# Altium schematic sheet DC Power Conversion.SchDoc
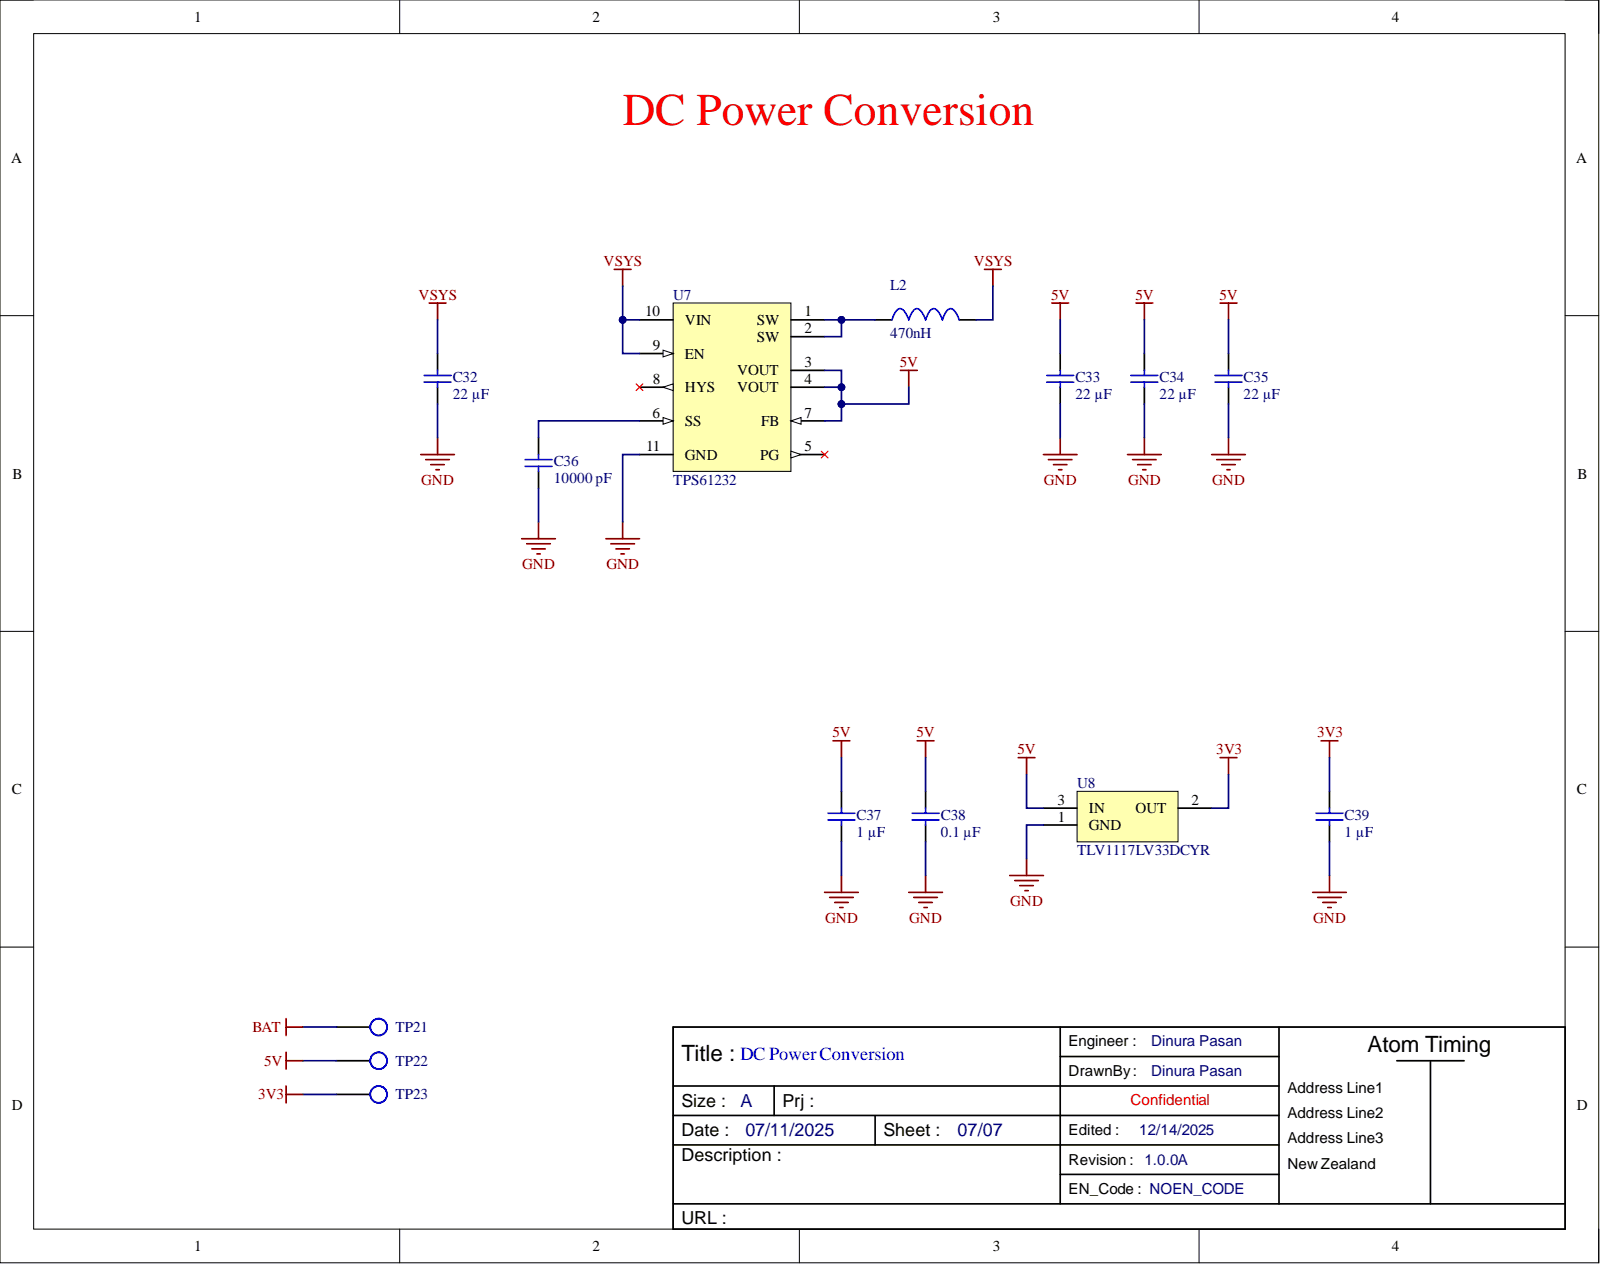
[diagram p0: DC Power Conversion.SchDoc]

## Parts
C32  22 µF  [TDK_CGA1206N]
C33  22 µF  [TDK_CGA1206N]
C34  22 µF  [TDK_CGA1206N]
C35  22 µF  [TDK_CGA1206N]
C36  10000 pF  [TDK_CGA0402N]
C37  1 µF  [TDK_CGA0805N]
C38  0.1 µF  [TDK_CGA0805N]
C39  1 µF  [TDK_CGA0805N]
L2  470nH  [XFL4015-471MEC]
TP21  TestPoint  [TESTPOINT]  (value not drawn)
TP22  TestPoint  [TESTPOINT]  (value not drawn)
TP23  TestPoint  [TESTPOINT]  (value not drawn)
U7  TPS61232  [TI-DRC0010G]
U8  TLV1117LV33DCYR  [TLV1117LV33DCYR]

## Nets
3V3: C39.2 TP23.1 U8.2
5V: C33.2 C34.2 C35.2 C37.2 C38.2 TP22.1 U7.3(VOUT) U7.4(VOUT) U7.7(FB) U8.3
BAT: TP21.1
GND: C32.1 C33.1 C34.1 C35.1 C36.1 C37.1 C38.1 C39.1 U7.11(GND) U8.1
VSYS: C32.2 L2.2 U7.9(EN) U7.10(VIN)
NetC36_2: C36.2 U7.6(SS)
NetL2_1: L2.1 U7.1(SW) U7.2(SW)

Not connected: U7 5(PG) 8(HYS)

## Library data of the parts
C32-C35 = CGA5L1X5R1C226M160AC: 22 µF ±20% 16V X5R -55°C ~ 85°C 1206 (3216 Metric); Manufacturer: TDK Corporation; Database Table Name: Sheet1$; Eco Plan: RoHS & Green; Initial Voltage: 0V; TEMP: 25; Value: 22u; Capacitance: 22 µF; Category: Ceramic Capacitor (MLCC); Component Kind: Standard; Datasheet: https://product.tdk.com/system/files/dam/doc/product/capacitor/ceramic/mlcc/catalog/mlcc_automotive_general_en.pdf; Library Name: MLCC.DbLib; Manufacturer Part Number: CGA5L1X5R1C226M160AC; Operating Temperature: -55°C ~ 85°C; Package / Case: 1206 (3216 Metric); Ratings: AEC-Q200; Series: CGA; Simulation: C_Capacitor_Default; Size / Dimension: 0.126" L x 0.063" W (3.20mm x 1.60mm); Supplier 1: Digi-Key; Supplier Part Number 1: 445-12847-2-ND; Temperature Coefficient: X5R; Thickness (Max): 0.075" (1.90mm); Tolerance: ±20%; Voltage - Rated: 16V
C36 = CGA2B3X7R1H103K050BB: 10000 pF ±10% 50V X7R -55°C ~ 125°C 0402 (1005 Metric); Manufacturer: TDK Corporation; Database Table Name: Sheet1$; Eco Plan: RoHS & Green; Initial Voltage: 0V; TEMP: 25; Value: 10000p; Capacitance: 10000 pF; Category: Ceramic Capacitor (MLCC); Component Kind: Standard; Datasheet: https://product.tdk.com/system/files/dam/doc/product/capacitor/ceramic/mlcc/catalog/mlcc_automotive_general_en.pdf; Library Name: MLCC.DbLib; Manufacturer Part Number: CGA2B3X7R1H103K050BB; Operating Temperature: -55°C ~ 125°C; Package / Case: 0402 (1005 Metric); Ratings: AEC-Q200; Series: CGA; Simulation: C_Capacitor_Default; Size / Dimension: 0.039" L x 0.020" W (1.00mm x 0.50mm); Supplier 1: Digi-Key; Supplier Part Number 1: 445-6893-2-ND; Temperature Coefficient: X7R; Thickness (Max): 0.022" (0.55mm); Tolerance: ±10%; Voltage - Rated: 50V
C37 C39 = CGA4J3X7R1H105K125AB: 1 µF ±10% 50V X7R -55°C ~ 125°C 0805 (2012 Metric); Manufacturer: TDK Corporation; Database Table Name: Sheet1$; Eco Plan: RoHS & Green; Initial Voltage: 0V; TEMP: 25; Value: 1u; Capacitance: 1 µF; Category: Ceramic Capacitor (MLCC); Component Kind: Standard; Datasheet: https://product.tdk.com/system/files/dam/doc/product/capacitor/ceramic/mlcc/catalog/mlcc_automotive_general_en.pdf; Library Name: MLCC.DbLib; Manufacturer Part Number: CGA4J3X7R1H105K125AB; Operating Temperature: -55°C ~ 125°C; Package / Case: 0805 (2012 Metric); Ratings: AEC-Q200; Series: CGA; Simulation: C_Capacitor_Default; Size / Dimension: 0.079" L x 0.049" W (2.00mm x 1.25mm); Supplier 1: Digi-Key; Supplier Part Number 1: 445-6961-2-ND; Temperature Coefficient: X7R; Thickness (Max): 0.059" (1.50mm); Tolerance: ±10%; Voltage - Rated: 50V
C38 = CGA4J2X7R2A104K125AE: 0.1 µF ±10% 100V X7R -55°C ~ 125°C 0805 (2012 Metric); Manufacturer: TDK Corporation; Database Table Name: Sheet1$; Eco Plan: RoHS & Green; Initial Voltage: 0V; TEMP: 25; Value: 0.1u; Capacitance: 0.1 µF; Category: Ceramic Capacitor (MLCC); Component Kind: Standard; Datasheet: https://product.tdk.com/system/files/dam/doc/product/capacitor/ceramic/mlcc/catalog/mlcc_automotive_general_en.pdf; Library Name: MLCC.DbLib; Manufacturer Part Number: CGA4J2X7R2A104K125AE; Operating Temperature: -55°C ~ 125°C; Package / Case: 0805 (2012 Metric); Ratings: AEC-Q200; Series: CGA; Simulation: C_Capacitor_Default; Size / Dimension: 0.079" L x 0.049" W (2.00mm x 1.25mm); Supplier 1: Digi-Key; Supplier Part Number 1: 445-15961-2-ND; Temperature Coefficient: X7R; Thickness (Max): 0.059" (1.50mm); Tolerance: ±10%; Voltage - Rated: 100V
L2 = XFL4015-471MEC: 470 nH Shielded Molded Inductor 9.1 A 8.36mOhm Max 1616 (4040 Metric); Manufacturer 1: Coilcraft; Case/Package 1: 1616; Category 1: Fixed Inductors; Core Material: Composite; DC Resistance (DCR): 8.36mΩ; Description 1: General Purpose Inductor, 0.47uH, 20%, 1 Element, Composite-Core, SMD, 1616; Max Current Rating: 11.2A; Number of Circuits: 1; Saturation Current: 3.5A; Self Resonant Frequency: 89MHz; Shielding: Yes; Test Frequency: 1MHz; Tolerance: 20%; Ambient Temperature Range High: 125°C; Component Kind: Standard; ComponentLink1Description: https://datasheet.octopart.com:443/XFL4015-471MEC-Coilcraft-datasheet-180122275.pdf?src-supplier=Newark; ComponentLink1URL: https://datasheet.octopart.com:443/XFL4015-471MEC-Coilcraft-datasheet-180122275.pdf?src-supplier=Newark; ComponentLink2Description: https://datasheet.octopart.com:443/XFL4015-471MEC-Coilcraft-datasheet-14354400.pdf?src-supplier=Newark; ComponentLink2URL: https://datasheet.octopart.com:443/XFL4015-471MEC-Coilcraft-datasheet-14354400.pdf?src-supplier=Newark; Height: 1.6mm; Inductance: 470nH; Lead Free 1: Contains Lead; Length: 4.3mm; Library Name: Components.IntLib; Lifecycle Status: Production; Manufacturer Part Number 1: XFL4015-471MEC; Max DC Current: 11.2A; Max Operating Temperature 1: 125°C; Min Operating Temperature 1: -40°C; Number of Terminals: 2; REACH SVHC: Yes; RMS Current (Irms): 11.2A; RoHS 1: Compliant; Series Resistance: 8.36mΩ; Supplier 1: LCSC; Supplier Part Number 1: C18221164; Termination: SMD/SMT; Width: 4mm
TP21-TP23 = TestPoint: 1mm Test Point; Component Kind: Standard; Library Name: Common_library.IntLib
U7 = TPS61232: 5-A, 5-V Fixed Output Voltage High Efficiency Synchronous Boost Converter; Manufacturer 1: Texas Instruments; Manufacturer Lifecycle Status: ACTIVE; Manufacturer Part Number 1: TPS61232DRCR; Category 1: Voltage Regulators - Switching; Description 1: Switching Regulator, Current-mode, 6A, 2000kHz Switching Freq-Max, BICMOS, PDSO10; Efficiency: 96%; Max Duty Cycle: 90%; Max Input Voltage: 5.5V; Max Junction Temperature (Tj): 125°C; Max Output Current 1: 2.1A; Min Input Voltage: 2.3V; Mount 1: Surface Mount; Number of Outputs 1: 1; Number of Pins 1: 10; Output Current 1: 5A; Output Voltage 1: 5V; Quiescent Current: 35µA; Switching Frequency: 2MHz; Component Kind: Standard; ComponentLink1Description: https://octopart.com:443/opatz8j6/c1?t=2GWtLKWaxkQjmDKCMiYp00vJSSZ6Dqq9CA-ZWt9kwYM6DX5ubETJ-My9t6Qr4BZEXr19lv6A2i-CshoPxpi94K44nAW2jEyEE6zKs7z5-oRQGsJxNSBgvcb6f20WCk7LcdIe30JwLkG3nK1R1Xb9Hxk3Cg-SRFlUkcLkSJVF01dwP3l53ZDBSz9xJsjXTl4r7N5XTrMGIaymN0CwyxUnNU4cmdNf9vRAvJvr57abplrAd1pVnWo8BucYefAgIB4yZHuUHMiIzXwaB4SkerCA1WYVJC1Conw6VGBGuORAGHjLjR_x7UK9rfgU-A0cQOeklwRook9k_VAM3E9OKgiQykZE8gQDOaTounc8ydU2ZqOfHFZe-mLkJ7n_izJa3DP4XXx4mos&src-supplier=Texas+Instruments; ComponentLink1URL: https://octopart.com:443/opatz8j6/c1?t=2GWtLKWaxkQjmDKCMiYp00vJSSZ6Dqq9CA-ZWt9kwYM6DX5ubETJ-My9t6Qr4BZEXr19lv6A2i-CshoPxpi94K44nAW2jEyEE6zKs7z5-oRQGsJxNSBgvcb6f20WCk7LcdIe30JwLkG3nK1R1Xb9Hxk3Cg-SRFlUkcLkSJVF01dwP3l53ZDBSz9xJsjXTl4r7N5XTrMGIaymN0CwyxUnNU4cmdNf9vRAvJvr57abplrAd1pVnWo8BucYefAgIB4yZHuUHMiIzXwaB4SkerCA1WYVJC1Conw6VGBGuORAGHjLjR_x7UK9rfgU-A0cQOeklwRook9k_VAM3E9OKgiQykZE8gQDOaTounc8ydU2ZqOfHFZe-mLkJ7n_izJa3DP4XXx4mos&src-supplier=Texas+Instruments; ComponentLink2Description: https://sigma.octopart.com:443/104365911/technical_drawing/Texas-Instruments-TPS61232DRCR.pdf?src-supplier=Texas+Instruments; ComponentLink2URL: https://sigma.octopart.com:443/104365911/technical_drawing/Texas-Instruments-TPS61232DRCR.pdf?src-supplier=Texas+Instruments; ComponentLink3Description: https://datasheet.octopart.com:443/TPS61232DRCR-Texas-Instruments-datasheet-41205295.pdf?src-supplier=Texas+Instruments; ComponentLink3URL: https://datasheet.octopart.com:443/TPS61232DRCR-Texas-Instruments-datasheet-41205295.pdf?src-supplier=Texas+Instruments; ComponentLink4Description: https://datasheet.octopart.com:443/TPS61232DRCR-Texas-Instruments-datasheet-32868995.pdf?src-supplier=Texas+Instruments; ComponentLink4URL: https://datasheet.octopart.com:443/TPS61232DRCR-Texas-Instruments-datasheet-32868995.pdf?src-supplier=Texas+Instruments; ComponentLink5Description: https://datasheet.octopart.com:443/TPS61232DRCR-Texas-Instruments-datasheet-27316741.pdf?src-supplier=Texas+Instruments; ComponentLink5URL: https://datasheet.octopart.com:443/TPS61232DRCR-Texas-Instruments-datasheet-27316741.pdf?src-supplier=Texas+Instruments; ComponentLink6Description: https://sigma.octopart.com:443/49250247/technical_drawing/Texas-Instruments-TPS61232DRCR.pdf?src-supplier=Texas+Instruments; ComponentLink6URL: https://sigma.octopart.com:443/49250247/technical_drawing/Texas-Instruments-TPS61232DRCR.pdf?src-supplier=Texas+Instruments; ComponentLink7Description: https://datasheet.octopart.com:443/TPS61232DRCR-Texas-Instruments-datasheet-148764816.pdf?src-supplier=Upverter; ComponentLink7URL: https://datasheet.octopart.com:443/TPS61232DRCR-Texas-Instruments-datasheet-148764816.pdf?src-supplier=Upverter; ComponentLink8Description: https://datasheet.octopart.com:443/TPS61232DRCR-Texas-Instruments-datasheet-159118193.pdf?src-supplier=LCSC; ComponentLink8URL: https://datasheet.octopart.com:443/TPS61232DRCR-Texas-Instruments-datasheet-159118193.pdf?src-supplier=LCSC; ComponentLink9Description: http://sigma.octopart.com:80/conflict_mineral_statement/Texas-Instruments-company-63.pdf?src-supplier=Compliance+Statements; ComponentLink9URL: http://sigma.octopart.com:80/conflict_mineral_statement/Texas-Instruments-company-63.pdf?src-supplier=Compliance+Statements; ComponentLink10Description: http://sigma.octopart.com:80/reach_statement/Texas-Instruments-company-64.pdf?src-supplier=Compliance+Statements; ComponentLink10URL: http://sigma.octopart.com:80/reach_statement/Texas-Instruments-company-64.pdf?src-supplier=Compliance+Statements; Contact Plating: Gold; Height: 1mm; Lead Free 1: Lead Free; Length: 3mm; Library Name: ICs.IntLib; Lifecycle Status: Production; Manufacturer Part Number 2: TPS61232DRCT; Max Operating Temperature 1: 85°C; Max Supply Voltage 1: 5.5V; Min Operating Temperature 1: -40°C; Min Supply Voltage 1: 2.3V; Operating Supply Voltage: 5.5V; Output Type: Fixed; RoHS 1: Compliant; Schedule B: 8542390000, 8542390000|8542390000|8542390000|8542390000|8542390000; Simulation: TPS61232_TRANS; Supplier 1: DigiKey; Supplier 2: DigiKey; Supplier 3: DigiKey; Supplier 4: Mouser; Supplier 5: LCSC; Supplier 6: Arrow Electronics; Supplier 7: DigiKey; Supplier 8: DigiKey; Supplier 9: DigiKey; Supplier 10: Mouser; Supplier Part Number 1: 296-44498-1-ND; Supplier Part Number 2: 296-44498-6-ND; Supplier Part Number 3: 296-44498-2-ND; Supplier Part Number 4: 595-TPS61232DRCR; Supplier Part Number 5: C544315; Supplier Part Number 6: TPS61232DRCT; Supplier Part Number 7: 296-37990-1-ND; Supplier Part Number 8: 296-37990-6-ND; Supplier Part Number 9: 296-37990-2-ND; Supplier Part Number 10: 595-TPS61232DRCT; Thickness: 900µm; Topology: Boost; Width: 3mm
U8 = TLV1117LV33DCYR: Linear Voltage Regulator IC Positive Fixed 1 Output 1A SOT-223-4; Manufacturer 1: Texas Instruments; Manufacturer Lifecycle Status: ACTIVE; Accuracy: 2%; Category 1: LDO Voltage Regulators; Description 1: IC REG LINEAR 3.3V 1A SOT223-4 Linear Voltage Regulator IC Positive Fixed 1 Output 1A SOT-223-4; Dropout Voltage: 455mV; Max Input Voltage: 5.5V; Max Output Voltage: 3.3V; Max Power Dissipation: 1.59W; Min Current Limit: 1.1A; Min Input Voltage: 2V; Number of Channels: 1; Number of Pins 1: 4; Output Voltage Accuracy: 1.5%; Quiescent Current: 50µA; Case/Package 1: SOT-223; Component Kind: Standard; ComponentLink1Description: https://octopart.com:443/opatz8j6/c1?t=VkzyEtRC3AH6QfO2immCHj2f7aYCda4duw9NQ-bWXcs1E7bz_IL30GN037FyO_pHcviG3io296cvU0B6kwzTnKrJWyRTG3D5vntziS-rakEBpvRGBBNupN6FRD-yBUl2uxzAgvtXWI-8pFw1H6n450wTNop_iqGgjP_PvA1q6x4bFJ7Jla1Gc3OYGOtuxBL3ccCoBMGe0bYjTYkKundwX_wGCSlZOm7bRKW5HTloZsW3JRY7LWmU1sjkKnUORf1u7zfCbF_7lAOIeiT0-X07mu4xS5fYdxdIgMidpb2l_M60kOhLus2iO8PGIvk1cDWL1Q3BLTkNwwLJl7254z3AgBPSblat37UKwC7J3TOKhQfFPye_x4oLCuedejtP4WdPl5CIGsK5&src-supplier=Texas+Instruments; ComponentLink1URL: https://octopart.com:443/opatz8j6/c1?t=VkzyEtRC3AH6QfO2immCHj2f7aYCda4duw9NQ-bWXcs1E7bz_IL30GN037FyO_pHcviG3io296cvU0B6kwzTnKrJWyRTG3D5vntziS-rakEBpvRGBBNupN6FRD-yBUl2uxzAgvtXWI-8pFw1H6n450wTNop_iqGgjP_PvA1q6x4bFJ7Jla1Gc3OYGOtuxBL3ccCoBMGe0bYjTYkKundwX_wGCSlZOm7bRKW5HTloZsW3JRY7LWmU1sjkKnUORf1u7zfCbF_7lAOIeiT0-X07mu4xS5fYdxdIgMidpb2l_M60kOhLus2iO8PGIvk1cDWL1Q3BLTkNwwLJl7254z3AgBPSblat37UKwC7J3TOKhQfFPye_x4oLCuedejtP4WdPl5CIGsK5&src-supplier=Texas+Instruments; ComponentLink2Description: https://datasheet.octopart.com:443/TLV1117LV33DCYR-Texas-Instruments-datasheet-11473775.pdf?src-supplier=Texas+Instruments; ComponentLink2URL: https://datasheet.octopart.com:443/TLV1117LV33DCYR-Texas-Instruments-datasheet-11473775.pdf?src-supplier=Texas+Instruments; ComponentLink3Description: https://datasheet.octopart.com:443/TLV1117LV33DCYR-Texas-Instruments-datasheet-10384188.pdf?src-supplier=Texas+Instruments; ComponentLink3URL: https://datasheet.octopart.com:443/TLV1117LV33DCYR-Texas-Instruments-datasheet-10384188.pdf?src-supplier=Texas+Instruments; ComponentLink4Description: https://datasheet.octopart.com:443/TLV1117LV33DCYR-Texas-Instruments-datasheet-10258012.pdf?src-supplier=Texas+Instruments; ComponentLink4URL: https://datasheet.octopart.com:443/TLV1117LV33DCYR-Texas-Instruments-datasheet-10258012.pdf?src-supplier=Texas+Instruments; ComponentLink5Description: https://sigma.octopart.com:443/49253063/technical_drawing/Texas-Instruments-TLV1117LV33DCYR.pdf?src-supplier=Texas+Instruments; ComponentLink5URL: https://sigma.octopart.com:443/49253063/technical_drawing/Texas-Instruments-TLV1117LV33DCYR.pdf?src-supplier=Texas+Instruments; ComponentLink6Description: https://datasheet.octopart.com:443/TLV1117LV33DCYR-Texas-Instruments-datasheet-45326244.pdf?src-supplier=Upverter; ComponentLink6URL: https://datasheet.octopart.com:443/TLV1117LV33DCYR-Texas-Instruments-datasheet-45326244.pdf?src-supplier=Upverter; ComponentLink7Description: https://datasheet.octopart.com:443/TLV1117LV33DCYR-Texas-Instruments-datasheet-37484773.pdf?src-supplier=Newark; ComponentLink7URL: https://datasheet.octopart.com:443/TLV1117LV33DCYR-Texas-Instruments-datasheet-37484773.pdf?src-supplier=Newark; ComponentLink8Description: https://datasheet.octopart.com:443/TLV1117LV33DCYR-Texas-Instruments-datasheet-32750758.pdf?src-supplier=Farnell; ComponentLink8URL: https://datasheet.octopart.com:443/TLV1117LV33DCYR-Texas-Instruments-datasheet-32750758.pdf?src-supplier=Farnell; ComponentLink9Description: https://datasheet.octopart.com:443/TLV1117LV33DCYR-Texas-Instruments-datasheet-21221901.pdf?src-supplier=Farnell; ComponentLink9URL: https://datasheet.octopart.com:443/TLV1117LV33DCYR-Texas-Instruments-datasheet-21221901.pdf?src-supplier=Farnell; ComponentLink10Description: https://datasheet.octopart.com:443/TLV1117LV33DCYR-Texas-Instruments-datasheet-164418789.pdf?src-supplier=Augswan; ComponentLink10URL: https://datasheet.octopart.com:443/TLV1117LV33DCYR-Texas-Instruments-datasheet-164418789.pdf?src-supplier=Augswan; ComponentLink11Description: https://datasheet.octopart.com:443/TLV1117LV33DCYR-Texas-Instruments-datasheet-165804599.pdf?src-supplier=LCSC; ComponentLink11URL: https://datasheet.octopart.com:443/TLV1117LV33DCYR-Texas-Instruments-datasheet-165804599.pdf?src-supplier=LCSC; ComponentLink12Description: https://datasheet.octopart.com:443/TLV1117LV33DCYR-Texas-Instruments-datasheet-159117777.pdf?src-supplier=LCSC; ComponentLink12URL: https://datasheet.octopart.com:443/TLV1117LV33DCYR-Texas-Instruments-datasheet-159117777.pdf?src-supplier=LCSC; ComponentLink13Description: https://datasheet.octopart.com:443/TLV1117LV33DCYR-Texas-Instruments-datasheet-137316104.pdf?src-supplier=Future+Electronics; ComponentLink13URL: https://datasheet.octopart.com:443/TLV1117LV33DCYR-Texas-Instruments-datasheet-137316104.pdf?src-supplier=Future+Electronics; ComponentLink14Description: https://datasheet.octopart.com:443/TLV1117LV33DCYR-Texas-Instruments-datasheet-11788568.pdf?src-supplier=Arrow+Electronics; ComponentLink14URL: https://datasheet.octopart.com:443/TLV1117LV33DCYR-Texas-Instruments-datasheet-11788568.pdf?src-supplier=Arrow+Electronics; ComponentLink15Description: http://sigma.octopart.com:80/conflict_mineral_statement/Texas-Instruments-company-63.pdf?src-supplier=Compliance+Statements; ComponentLink15URL: http://sigma.octopart.com:80/conflict_mineral_statement/Texas-Instruments-company-63.pdf?src-supplier=Compliance+Statements; ComponentLink16Description: http://sigma.octopart.com:80/reach_statement/Texas-Instruments-company-64.pdf?src-supplier=Compliance+Statements; ComponentLink16URL: http://sigma.octopart.com:80/reach_statement/Texas-Instruments-company-64.pdf?src-supplier=Compliance+Statements; Height: 1.8mm; Lead Free 1: Lead Free; Length: 6.5mm; Library Name: Components.IntLib; Lifecycle Status: Production; Manufacturer Part Number 1: TLV1117LV33DCYR; Max Operating Temperature 1: 125°C; Max Output Current 1: 1A; Min Operating Temperature 1: -40°C; Min Output Voltage: 3.3V; Nominal Output Voltage: 3.3V; Number of Outputs 1: 1; Number of Regulators: 1; Output Current 1: 1A; Output Type: Fixed; Output Voltage 1: 3.3V; Polarity: Positive; Power Dissipation 1: 1.59W; Radiation Hardening: No; REACH SVHC: No; RoHS 1: Compliant; Schedule B: 8542390000, 8542390000|8542390000|8542390000|8542390000|8542390000; Supplier 1: DigiKey; Supplier Part Number 1: 296-28778-1-ND; Thickness: 1.6mm; Width: 3.5mm
